# Altium schematic sheet main.SchDoc
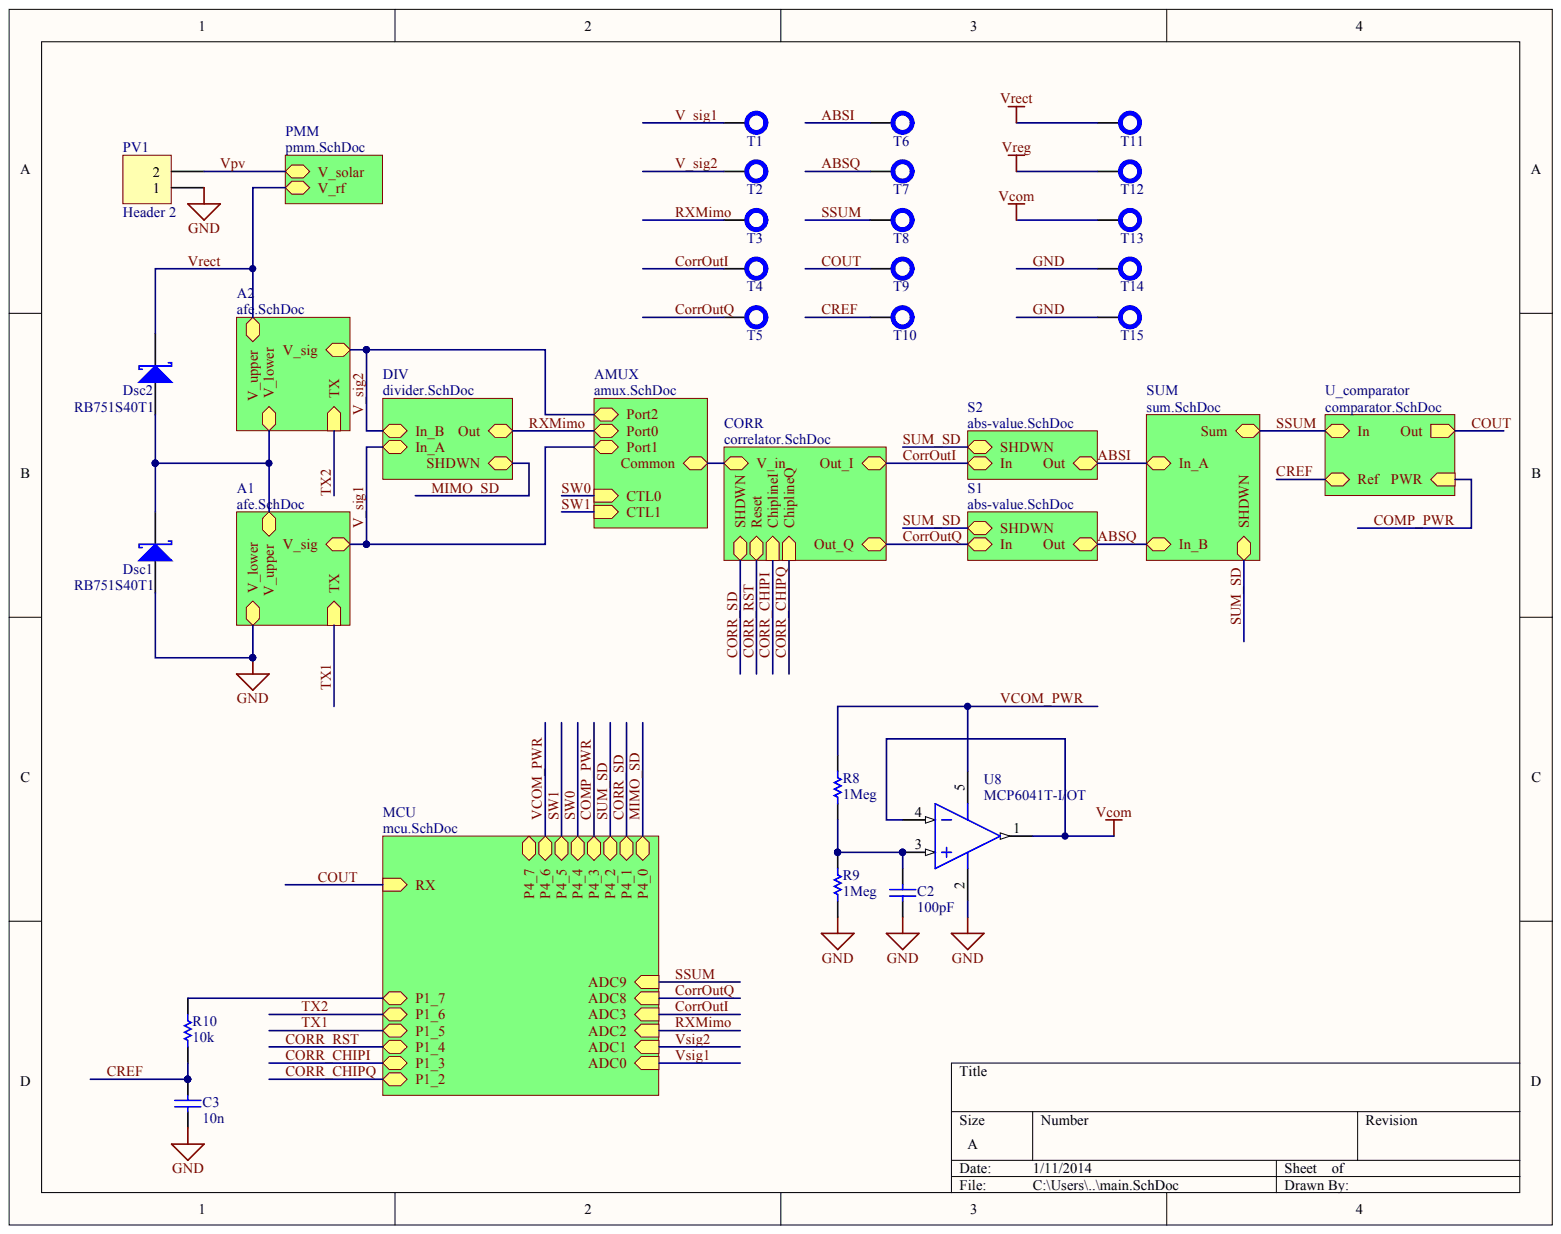
[diagram p0: main.SchDoc]

## Parts
C2  value 100pF  (hidden comment: Cap)
C3  value 10n  (hidden comment: Cap)
Dsc1  RB751S40T1
Dsc2  RB751S40T1
PV1  Header 2
R8  value 1Meg  (hidden comment: Res)
R9  value 1Meg  (hidden comment: Res)
R10  value 10k  (hidden comment: Res)
T1  Test Point  (value not drawn)
T2  Test Point  (value not drawn)
T3  Test Point  (value not drawn)
T4  Test Point  (value not drawn)
T5  Test Point  (value not drawn)
T6  Test Point  (value not drawn)
T7  Test Point  (value not drawn)
T8  Test Point  (value not drawn)
T9  Test Point  (value not drawn)
T10  Test Point  (value not drawn)
T11  Test Point  (value not drawn)
T12  Test Point  (value not drawn)
T13  Test Point  (value not drawn)
T14  Test Point  (value not drawn)
T15  Test Point  (value not drawn)
U8  MCP6041T-I/OT

## Nets
VCOM: T13.0 U8.1 U8.4
VREG: T12.0
ABSI: T6.0
ABSQ: T7.0
CORROUTI: T4.0
CORROUTQ: T5.0
COUT: T9.0
CREF: C3.2 R10.1 T10.0
GND: C2.1 C3.1 Dsc1.2 PV1.1 R9.1 T14.0 T15.0 U8.2
RXMIMO: T3.0
SSUM: T8.0
V_SIG1: T1.0
V_SIG2: T2.0
VCOM_PWR: R8.2 U8.5
VPV: PV1.2
VRECT: Dsc2.1 T11.0
NetC2_2: C2.2 R8.1 R9.2 U8.3
NetDsc1_1: Dsc1.1 Dsc2.2
NetR10_2: R10.2
COMMON:
COMP_PWR:
CORR_CHIPI:
CORR_CHIPQ:
CORR_RST:
CORR_SD:
MIMO_SD:
P4_7:
SUM_SD:
SW0:
SW1:
TX1:
TX2:
VSIG1:
VSIG2:

## Library data of the parts
C2 C3 = (no symbol name): Manufacturer: Generic Ceramic Chip Cap (TBD); Digi-Key Part Number: *; Manufacturer Part Number: *; Note: *; Rating: *
R8-R10 = (no symbol name): Manufacturer: Generic Chip Res (TBD); Manufacturer Part Number: *; Digi-Key Part Number: *; Rating: *
T1-T15 = (no symbol name): Manufacturer Part Number: Example: 5000, 5013; Manufacturer: Keystone Electronics; Digi-key: Example: 5000K-ND, 5012K-ND; Note: *; Rating: *; Value: *
Dsc1 Dsc2 PV1 U8 = (no symbol name)
  Dsc1 Dsc2: Published: 8-Jun-2000; LatestRevisionDate: 17-Jul-2002; LatestRevisionNote: Re-released for DXP Platform.; PackageReference: SMB; Code_JEDEC: DO-214-AA
  PV1: LatestRevisionDate: 17-Jul-2002; LatestRevisionNote: Re-released for DXP Platform.
  U8: PackageDescription: 5-Lead Plastic Small Outline Transistor (OT) [SOT-23]; RevisionNote: URLs added.; DatasheetVersion: revC, Feb-2008; ComponentLink1URL: http://www.microchip.com/; ComponentLink2URL: http://ww1.microchip.com/downloads/en/DeviceDoc/21669c.pdf; ComponentLink2Description: Datasheet; PackageVersion: revBB, Aug-2009; ComponentLink3Description: Package Specification; PackageReference: SOT-23-OT5; ComponentLink1Description: Manufacturer URL; ComponentLink3URL: http://www.microchip.com/stellent/groups/techpub_sg/documents/packagingspec/en012702.pdf; Code_IPC: SOT23-5
  Dsc1 Dsc2 PV1: Publisher: Altium Limited
